# Altium schematic sheet SW.SchDoc(SW)
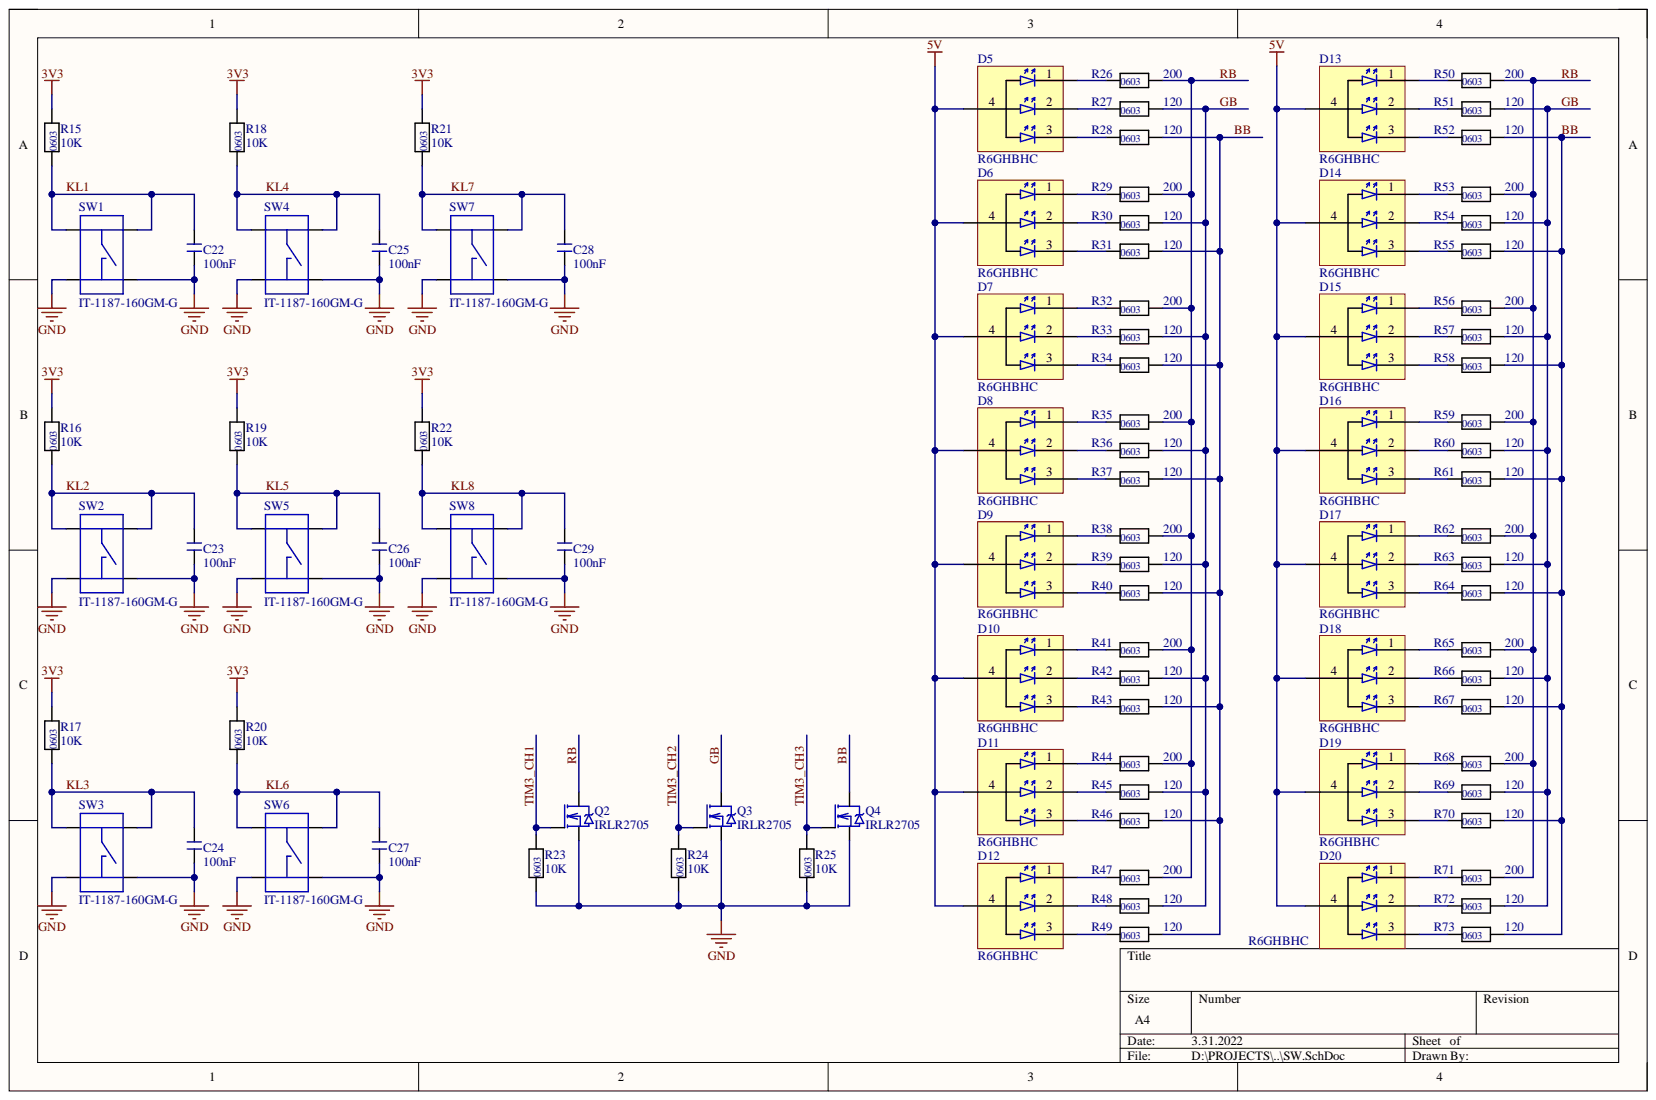
[diagram p0: SW.SchDoc]

## Parts
C22  100nF  [CHIP_C_0603]
C23  100nF  [CHIP_C_0603]
C24  100nF  [CHIP_C_0603]
C25  100nF  [CHIP_C_0603]
C26  100nF  [CHIP_C_0603]
C27  100nF  [CHIP_C_0603]
C28  100nF  [CHIP_C_0603]
C29  100nF  [CHIP_C_0603]
D5  R6GHBHC  [R6GHBHC]
D6  R6GHBHC  [R6GHBHC]
D7  R6GHBHC  [R6GHBHC]
D8  R6GHBHC  [R6GHBHC]
D9  R6GHBHC  [R6GHBHC]
D10  R6GHBHC  [R6GHBHC]
D11  R6GHBHC  [R6GHBHC]
D12  R6GHBHC  [R6GHBHC]
D13  R6GHBHC  [R6GHBHC]
D14  R6GHBHC  [R6GHBHC]
D15  R6GHBHC  [R6GHBHC]
D16  R6GHBHC  [R6GHBHC]
D17  R6GHBHC  [R6GHBHC]
D18  R6GHBHC  [R6GHBHC]
D19  R6GHBHC  [R6GHBHC]
D20  R6GHBHC  [R6GHBHC]
Q2  IRLR2705  [TO-252AA]
Q3  IRLR2705  [TO-252AA]
Q4  IRLR2705  [TO-252AA]
R15  10K  [CHIP_R_0603]
R16  10K  [CHIP_R_0603]
R17  10K  [CHIP_R_0603]
R18  10K  [CHIP_R_0603]
R19  10K  [CHIP_R_0603]
R20  10K  [CHIP_R_0603]
R21  10K  [CHIP_R_0603]
R22  10K  [CHIP_R_0603]
R23  10K  [CHIP_R_0603]
R24  10K  [CHIP_R_0603]
R25  10K  [CHIP_R_0603]
R26  200  [CHIP_R_0603]
R27  120  [CHIP_R_0603]
R28  120  [CHIP_R_0603]
R29  200  [CHIP_R_0603]
R30  120  [CHIP_R_0603]
R31  120  [CHIP_R_0603]
R32  200  [CHIP_R_0603]
R33  120  [CHIP_R_0603]
R34  120  [CHIP_R_0603]
R35  200  [CHIP_R_0603]
R36  120  [CHIP_R_0603]
R37  120  [CHIP_R_0603]
R38  200  [CHIP_R_0603]
R39  120  [CHIP_R_0603]
R40  120  [CHIP_R_0603]
R41  200  [CHIP_R_0603]
R42  120  [CHIP_R_0603]
R43  120  [CHIP_R_0603]
R44  200  [CHIP_R_0603]
R45  120  [CHIP_R_0603]
R46  120  [CHIP_R_0603]
R47  200  [CHIP_R_0603]
R48  120  [CHIP_R_0603]
R49  120  [CHIP_R_0603]
R50  200  [CHIP_R_0603]
R51  120  [CHIP_R_0603]
R52  120  [CHIP_R_0603]
R53  200  [CHIP_R_0603]
R54  120  [CHIP_R_0603]
R55  120  [CHIP_R_0603]
R56  200  [CHIP_R_0603]
R57  120  [CHIP_R_0603]
R58  120  [CHIP_R_0603]
R59  200  [CHIP_R_0603]
R60  120  [CHIP_R_0603]
R61  120  [CHIP_R_0603]
R62  200  [CHIP_R_0603]
R63  120  [CHIP_R_0603]
R64  120  [CHIP_R_0603]
R65  200  [CHIP_R_0603]
R66  120  [CHIP_R_0603]
R67  120  [CHIP_R_0603]
R68  200  [CHIP_R_0603]
R69  120  [CHIP_R_0603]
R70  120  [CHIP_R_0603]
R71  200  [CHIP_R_0603]
R72  120  [CHIP_R_0603]
R73  120  [CHIP_R_0603]
SW1  IT-1187-160GM-G  [IT-1187]
SW2  IT-1187-160GM-G  [IT-1187]
SW3  IT-1187-160GM-G  [IT-1187]
SW4  IT-1187-160GM-G  [IT-1187]
SW5  IT-1187-160GM-G  [IT-1187]
SW6  IT-1187-160GM-G  [IT-1187]
SW7  IT-1187-160GM-G  [IT-1187]
SW8  IT-1187-160GM-G  [IT-1187]

## Nets
3V3: R15.2 R16.2 R17.2 R18.2 R19.2 R20.2 R21.2 R22.2
5V: D5.4 D6.4 D7.4 D8.4 D9.4 D10.4 D11.4 D12.4 D13.4 D14.4 D15.4 D16.4 D17.4 D18.4 D19.4 D20.4
GND: C22.1 C23.1 C24.1 C25.1 C26.1 C27.1 C28.1 C29.1 Q2.3 Q3.3 Q4.3 R23.1 R24.1 R25.1 SW1.3 SW1.4 SW2.3 SW2.4 SW3.3 SW3.4 SW4.3 SW4.4 SW5.3 SW5.4 SW6.3 SW6.4 SW7.3 SW7.4 SW8.3 SW8.4
BB: Q4.4 R28.2 R31.2 R34.2 R37.2 R40.2 R43.2 R46.2 R49.2 R52.2 R55.2 R58.2 R61.2 R64.2 R67.2 R70.2 R73.2
GB: Q3.4 R27.2 R30.2 R33.2 R36.2 R39.2 R42.2 R45.2 R48.2 R51.2 R54.2 R57.2 R60.2 R63.2 R66.2 R69.2 R72.2
KL1: C22.2 R15.1 SW1.1 SW1.2
KL2: C23.2 R16.1 SW2.1 SW2.2
KL3: C24.2 R17.1 SW3.1 SW3.2
KL4: C25.2 R18.1 SW4.1 SW4.2
KL5: C26.2 R19.1 SW5.1 SW5.2
KL6: C27.2 R20.1 SW6.1 SW6.2
KL7: C28.2 R21.1 SW7.1 SW7.2
KL8: C29.2 R22.1 SW8.1 SW8.2
RB: Q2.4 R26.2 R29.2 R32.2 R35.2 R38.2 R41.2 R44.2 R47.2 R50.2 R53.2 R56.2 R59.2 R62.2 R65.2 R68.2 R71.2
TIM3_CH1: Q2.1 R23.2
TIM3_CH2: Q3.1 R24.2
TIM3_CH3: Q4.1 R25.2
NetD5_1: D5.1 R26.1
NetD5_2: D5.2 R27.1
NetD5_3: D5.3 R28.1
NetD6_1: D6.1 R29.1
NetD6_2: D6.2 R30.1
NetD6_3: D6.3 R31.1
NetD7_1: D7.1 R32.1
NetD7_2: D7.2 R33.1
NetD7_3: D7.3 R34.1
NetD8_1: D8.1 R35.1
NetD8_2: D8.2 R36.1
NetD8_3: D8.3 R37.1
NetD9_1: D9.1 R38.1
NetD9_2: D9.2 R39.1
NetD9_3: D9.3 R40.1
NetD10_1: D10.1 R41.1
NetD10_2: D10.2 R42.1
NetD10_3: D10.3 R43.1
NetD11_1: D11.1 R44.1
NetD11_2: D11.2 R45.1
NetD11_3: D11.3 R46.1
NetD12_1: D12.1 R47.1
NetD12_2: D12.2 R48.1
NetD12_3: D12.3 R49.1
NetD13_1: D13.1 R50.1
NetD13_2: D13.2 R51.1
NetD13_3: D13.3 R52.1
NetD14_1: D14.1 R53.1
NetD14_2: D14.2 R54.1
NetD14_3: D14.3 R55.1
NetD15_1: D15.1 R56.1
NetD15_2: D15.2 R57.1
NetD15_3: D15.3 R58.1
NetD16_1: D16.1 R59.1
NetD16_2: D16.2 R60.1
NetD16_3: D16.3 R61.1
NetD17_1: D17.1 R62.1
NetD17_2: D17.2 R63.1
NetD17_3: D17.3 R64.1
NetD18_1: D18.1 R65.1
NetD18_2: D18.2 R66.1
NetD18_3: D18.3 R67.1
NetD19_1: D19.1 R68.1
NetD19_2: D19.2 R69.1
NetD19_3: D19.3 R70.1
NetD20_1: D20.1 R71.1
NetD20_2: D20.2 R72.1
NetD20_3: D20.3 R73.1

## Library data of the parts
C22-C29 = CHIP_C: capacitor ceramic; Component Kind: Standard; Library Name: Popular.SchLib
D5-D20 = RGB: Component Kind: Standard; Library Name: Popular.SchLib
R15-R73 = RES_0603: Resistor; Component Kind: Standard; Library Name: Popular.SchLib
SW1-SW8 = SWITCH: Component Kind: Standard; Library Name: Popular.SchLib
Q2-Q4 = IRLR2705: Component Kind: Standard; Library Name: Popular.SchLib
